# Altium schematic sheet 4_Displays_rA1.SchDoc
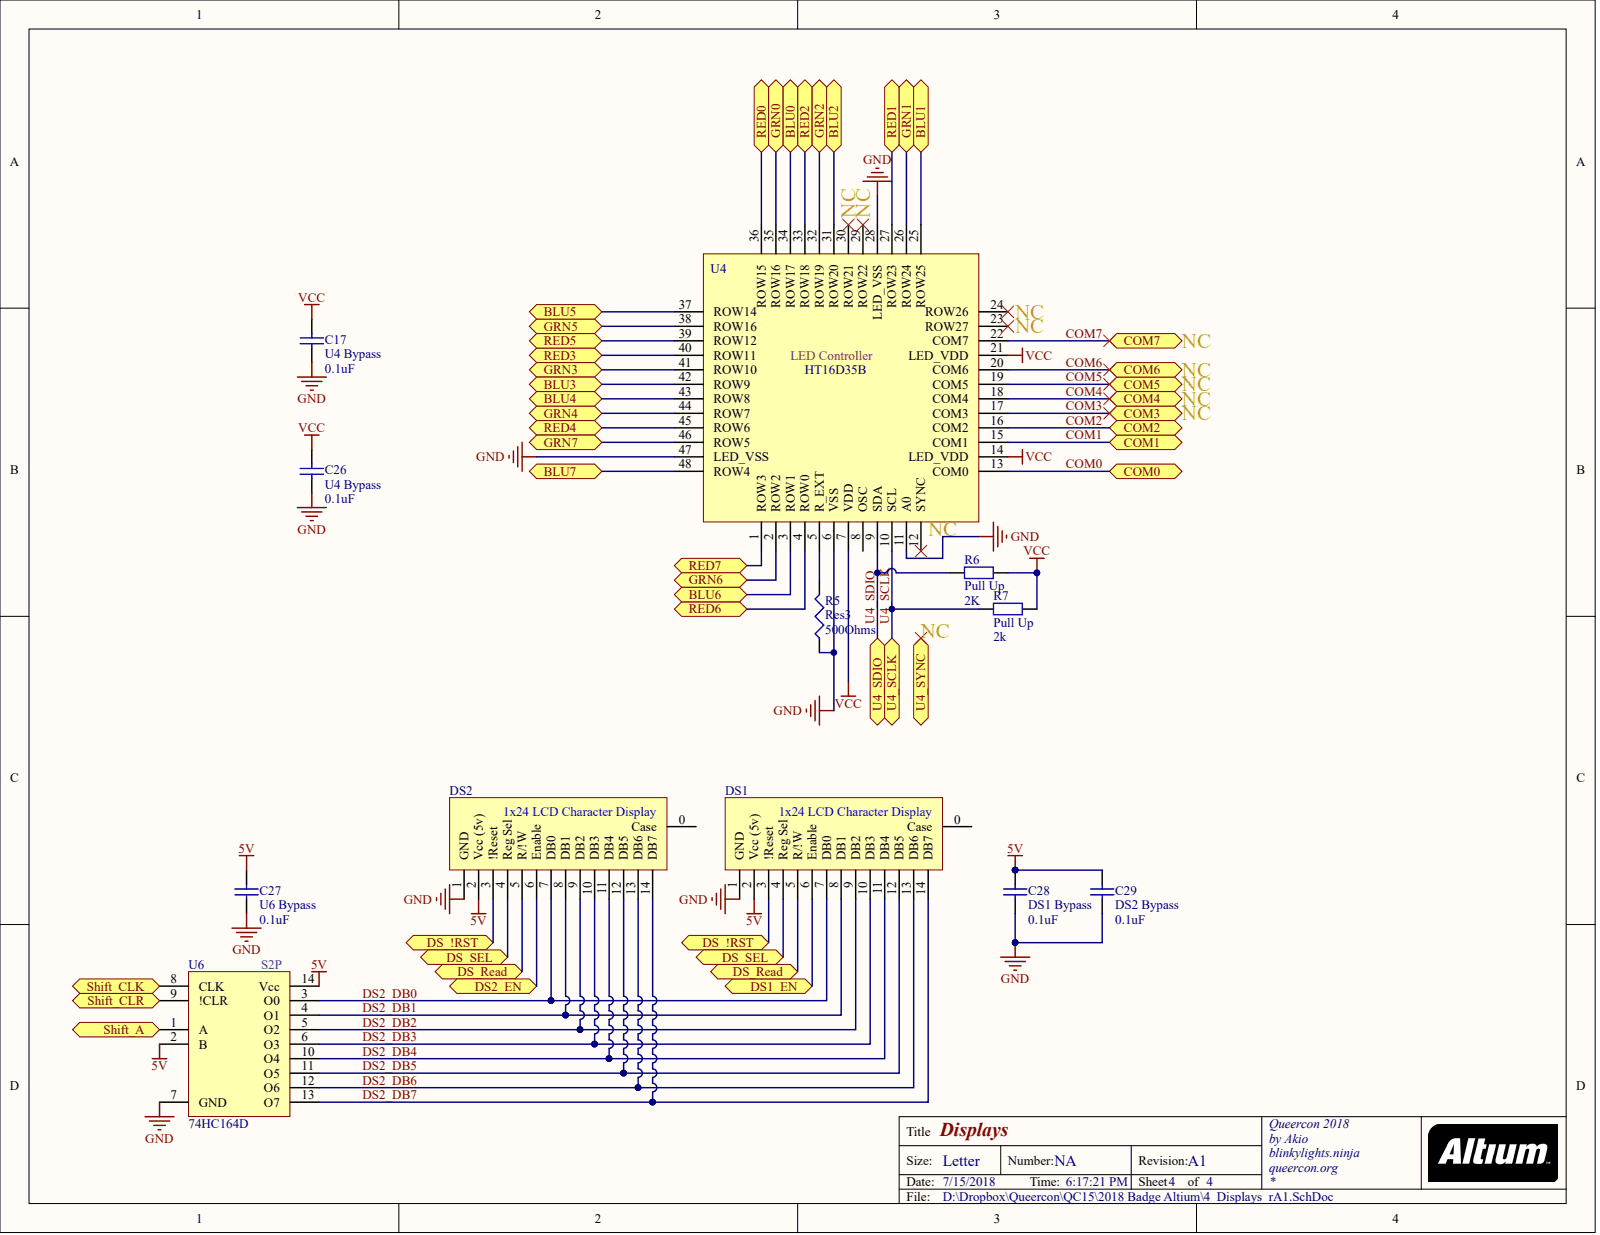
[diagram p0: 4_Displays_rA1.SchDoc]

## Parts
C17  U4 Bypass  value 0.1uF
C26  U4 Bypass  value 0.1uF
C27  U6 Bypass  value 0.1uF
C28  DS1 Bypass  value 0.1uF
C29  DS2 Bypass  value 0.1uF
DS1  1x24_LCD
DS2  1x24_LCD
R5  Res3  value 500Ohms
R6  Pull Up  value 2K
R7  Pull Up  value 2k
U4  HT16D35B
U6  74HC164D

## Ports
BLU0, BLU1, BLU2, BLU3, BLU4, BLU5, BLU6, BLU7, COM0, COM1, COM2, COM3, COM4, COM5, COM6, COM7, DS1_EN, DS2_EN, DS_!RST, DS_READ, DS_SEL, GRN0, GRN1, GRN2, GRN3, GRN4, GRN5, GRN6, GRN7, RED0, RED1, RED2, RED3, RED4, RED5, RED6, RED7, SHIFT_A, SHIFT_CLK, SHIFT_CLR, U4_SCLK, U4_SDIO, U4_SYNC

## Nets
5V: C27.2 C28.2 C29.2 DS1.2(Vcc_(5v)) DS2.2(Vcc_(5v)) U6.2(B) U6.14(Vcc)
GND: C17.1 C26.1 C27.1 C28.1 C29.1 DS1.1(GND) DS2.1(GND) R5.1 U4.6(VSS) U4.11(A0) U4.28(LED_VSS) U4.47(LED_VSS) U6.7(GND)
VCC: C17.2 C26.2 R6.1 R7.1 U4.7(VDD) U4.14(LED_VDD) U4.21(LED_VDD)
BLU0: U4.34(ROW17) ; port BLU0
BLU1: U4.25(ROW25) ; port BLU1
BLU2: U4.31(ROW20) ; port BLU2
BLU3: U4.42(ROW9) ; port BLU3
BLU4: U4.43(ROW8) ; port BLU4
BLU5: U4.37(ROW14) ; port BLU5
BLU6: U4.3(ROW1) ; port BLU6
BLU7: U4.48(ROW4) ; port BLU7
COM0: U4.13(COM0) ; port COM0
COM1: U4.15(COM1) ; port COM1
COM2: U4.16(COM2) ; port COM2
COM3: U4.17(COM3) ; port COM3
COM4: U4.18(COM4) ; port COM4
COM5: U4.19(COM5) ; port COM5
COM6: U4.20(COM6) ; port COM6
COM7: U4.22(COM7) ; port COM7
DS1_EN: DS1.6(Enable) ; port DS1_EN
DS2_DB0: DS1.7(DB0) DS2.7(DB0) U6.3(O0)
DS2_DB1: DS1.8(DB1) DS2.8(DB1) U6.4(O1)
DS2_DB2: DS1.9(DB2) DS2.9(DB2) U6.5(O2)
DS2_DB3: DS1.10(DB3) DS2.10(DB3) U6.6(O3)
DS2_DB4: DS1.11(DB4) DS2.11(DB4) U6.10(O4)
DS2_DB5: DS1.12(DB5) DS2.12(DB5) U6.11(O5)
DS2_DB6: DS1.13(DB6) DS2.13(DB6) U6.12(O6)
DS2_DB7: DS1.14(DB7) DS2.14(DB7) U6.13(O7)
DS2_EN: DS2.6(Enable) ; port DS2_EN
DS_!RST: DS1.3(!Reset) DS2.3(!Reset) ; port DS_!RST
DS_SEL: DS1.4(Reg_Sel) DS2.4(Reg_Sel) ; port DS_SEL
GRN0: U4.35(ROW16) ; port GRN0
GRN1: U4.26(ROW24) ; port GRN1
GRN2: U4.32(ROW19) ; port GRN2
GRN3: U4.41(ROW10) ; port GRN3
GRN4: U4.44(ROW7) ; port GRN4
GRN5: U4.38(ROW16) ; port GRN5
GRN6: U4.2(ROW2) ; port GRN6
GRN7: U4.46(ROW5) ; port GRN7
RED0: U4.36(ROW15) ; port RED0
RED1: U4.27(ROW23) ; port RED1
RED2: U4.33(ROW18) ; port RED2
RED3: U4.40(ROW11) ; port RED3
RED4: U4.45(ROW6) ; port RED4
RED5: U4.39(ROW12) ; port RED5
RED6: U4.4(ROW0) ; port RED6
RED7: U4.1(ROW3) ; port RED7
U4_SCLK: R7.2 U4.10(SCL) ; port U4_SCLK
U4_SDIO: R6.2 U4.9(SDA) ; port U4_SDIO
NetDS1_5: DS1.5(R/!W) DS2.5(R/!W) ; port DS_READ
NetR5_2: R5.2 U4.5(R_EXT)
NetU6_1: U6.1(A) ; port SHIFT_A
NetU6_8: U6.8(CLK) ; port SHIFT_CLK
NetU6_9: U6.9(!CLR) ; port SHIFT_CLR
N00144: ; port U4_SYNC

Not connected: DS1 0(Case); DS2 0(Case); U4 8(OSC) 12(SYNC) 23(ROW27) 24(ROW26) 29(ROW22) 30(ROW21)

## Library data of the parts
R6 = (no symbol name): Value: 2K; Published: 8-Jun-2000; LatestRevisionDate: 17-Jul-2002; LatestRevisionNote: Re-released for DXP Platform.; PackageReference: AXIAL-0.4; PackageDescription: Axial Device, Thru-Hole; 2 Leads; 0.4 in Pin Spacing; Publisher: Altium Limited
C17 C26-C29 DS1 DS2 R5 R7 U4 U6 = (no symbol name)
  C17 C26-C29: Published: 8-Jun-2000; LatestRevisionDate: 17-Jul-2002; LatestRevisionNote: Re-released for DXP Platform.; PackageReference: RAD-0.3; PackageDescription: Radial Cap, Thru-Hole; 2 Leads; 0.3 in Pin Spacing
  R5: Published: 8-Jun-2000; LatestRevisionDate: 29-May-2009; LatestRevisionNote: IPC-7351 Footprint Added.; PackageReference: J1-0603; PackageDescription: Chip Resistor
  R7: Published: 8-Jun-2000; LatestRevisionDate: 17-Jul-2002; LatestRevisionNote: Re-released for DXP Platform.; PackageReference: AXIAL-0.4; PackageDescription: Axial Device, Thru-Hole; 2 Leads; 0.4 in Pin Spacing
  C17 C26-C29 R5 R7: Publisher: Altium Limited
